# Altium schematic sheet 主拓扑.SchDoc(主拓扑)
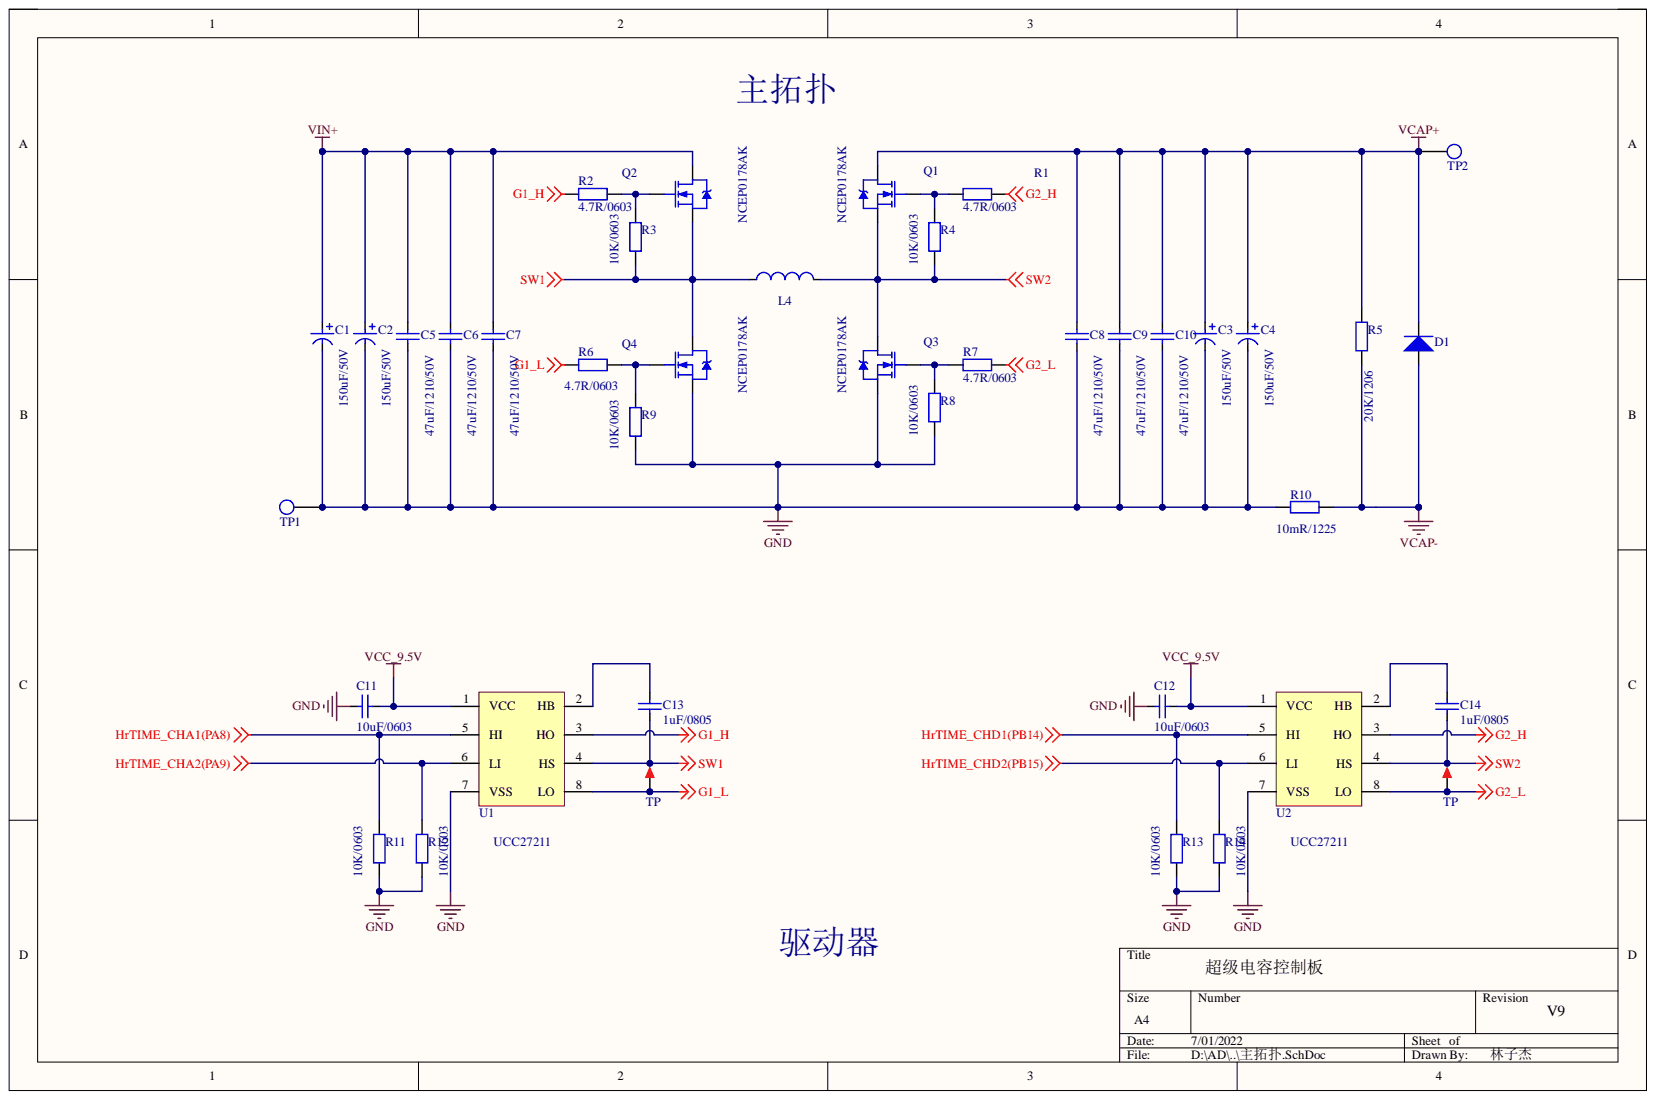
[diagram p0: 主拓扑.SchDoc]

## Parts
ADC_ILOW?  TP  [TEST_1.4TP]
ADC_ILOW?  TP  [TEST_1.4TP]
C1  150uF/50V  [CAP_CM(10*10.2)]
C2  150uF/50V  [CAP_CM(10*10.2)]
C3  150uF/50V  [CAP_CM(10*10.2)]
C4  150uF/50V  [CAP_CM(10*10.2)]
C5  47uF  value 47uF/1210/50V  [CAP_1210]
C6  47uF  value 47uF/1210/50V  [CAP_1210]
C7  47uF  value 47uF/1210/50V  [CAP_1210]
C8  47uF  value 47uF/1210/50V  [CAP_1210]
C9  47uF  value 47uF/1210/50V  [CAP_1210]
C10  47uF  value 47uF/1210/50V  [CAP_1210]
C11  10uF  value 10uF/0603  [CAP_0603]
C12  10uF  value 10uF/0603  [CAP_0603]
C13  1uF  value 1uF/0805  [CAP_0805]
C14  1uF  value 1uF/0805  [CAP_0805]
D1  SMAJ75A  [DO-214AC]  (value not drawn)
L4  47uH  [IND_20315]  (value not drawn)
Q1  NCEP0178AK  [TO-252]
Q2  NCEP0178AK  [TO-252]
Q3  NCEP0178AK  [TO-252]
Q4  NCEP0178AK  [TO-252]
R1  4.7R  value 4.7R/0603  [RES_0603]
R2  4.7R  value 4.7R/0603  [RES_0603]
R3  10K  value 10K/0603  [RES_0603]
R4  value 10K/0603  [RES_0603]  (hidden comment: 10K)
R5  20K  value 20K/1206  [RES_1206]
R6  4.7R  value 4.7R/0603  [RES_0603]
R7  4.7R  value 4.7R/0603  [RES_0603]
R8  value 10K/0603  [RES_0603]  (hidden comment: 10K)
R9  10K  value 10K/0603  [RES_0603]
R10  10mR  value 10mR/1225  [RES_1225]
R11  10K  value 10K/0603  [RES_0603]
R12  10K  value 10K/0603  [RES_0603]
R13  10K  value 10K/0603  [RES_0603]
R14  10K  value 10K/0603  [RES_0603]
TP1  ??  [TP]  (value not drawn)
TP2  ??  [TP]  (value not drawn)
U1  UCC27211  [SOP-8 - BIG]
U2  UCC27211  [SOP-8 - BIG]

## Nets
G1_H: R2.1 U1.3(HO)
G1_L: ADC_ILOW?.1 R6.1 U1.8(LO)
G2_H: R1.1 U2.3(HO)
G2_L: ADC_ILOW?.1 R7.1 U2.8(LO)
GND: C1.2 C2.2 C3.2 C4.2 C5.1 C6.1 C7.1 C8.1 C9.1 C10.1 C11.1 C12.1 Q3.3 Q4.3 R8.1 R9.1 R10.1 R11.1 R12.1 R13.1 R14.1 TP1.1 U1.7(VSS) U2.7(VSS)
HrTIME_CHA1(PA8): R11.2 U1.5(HI)
HrTIME_CHA2(PA9): R12.2 U1.6(LI)
HrTIME_CHD1(PB14): R13.2 U2.5(HI)
HrTIME_CHD2(PB15): R14.2 U2.6(LI)
SW1: C13.1 L4.1 Q2.3 Q4.2 R3.1 U1.4(HS)
SW2: C14.1 L4.2 Q1.3 Q3.2 R4.1 U2.4(HS)
VCAP+: C3.1 C4.1 C8.2 C9.2 C10.2 D1.2 Q1.2 R5.2 TP2.1
VCAP-: D1.1 R5.1 R10.2
VCC_9.5V: C11.2 C12.2 U1.1(VCC) U2.1(VCC)
VIN+: C1.1 C2.1 C5.2 C6.2 C7.2 Q2.2
NetC13_2: C13.2 U1.2(HB)
NetC14_2: C14.2 U2.2(HB)
NetQ1_1: Q1.1 R1.2 R4.2
NetQ2_1: Q2.1 R2.2 R3.2
NetQ3_1: Q3.1 R7.2 R8.2
NetQ4_1: Q4.1 R6.2 R9.2

## Library data of the parts
ADC_ILOW? = TEST TP: 极性电容; BOM名称: 测试环; 联系卖家，免费查找封装: 唯一出售店铺网址https://shop463601444.taobao.com; Component Kind: Standard; Library Name: 0.7 - 常用按键-开关-蜂鸣器-电池-测试点.SchLib
ADC_ILOW? = TEST TP: 测试环; 联系卖家，免费查找封装: 唯一出售店铺网址https://shop463601444.taobao.com; BOM名称: 测试环; Component Kind: Standard; Library Name: 0.7 - 常用按键-开关-蜂鸣器-电池-测试点.SchLib
C1-C4 = Cap_ELEC: 极性电容; Component Kind: Standard; Library Name: LIN_Library .SchLib
C5-C10 = Cap_1210: Capacitor; Component Kind: Standard; Library Name: LIN_Library .SchLib
C11 C12 = Cap_0603: Capacitor; Component Kind: Standard; Library Name: LIN_Library .SchLib
C13 C14 = Cap_0805: Capacitor; Component Kind: Standard; Library Name: LIN_Library .SchLib
D1 = Diode_SMA: Diode; Component Kind: Standard; Library Name: LIN_Library .SchLib
L4 = Ind: 磁环电感; Component Kind: Standard; Library Name: LIN_Library .SchLib
Q1-Q4 = MOSFET_N: N-MOSFET; Note: Pin 2 is electrically connected to pin 4.; Component Kind: Standard; ComponentLink1Description: Manufacturer Link; ComponentLink1URL: http://www.irf.com/; DatasheetVersion: PD-9.814; LatestRevisionDate: 17-Jul-2002; LatestRevisionNote: Re-released for DXP Platform.; PackageDescription: TO, Thru-Hole, Vertical, Heatsink Mounted; 3 In-Line Leads; Pitch 2.54 mm; PackageReference: TO-220AB; PackageVersion: Feb-1997; Published: 8-Jun-2000; Library Name: LIN_Library .SchLib; Publisher: Altium Limited
R1-R3 R6 R7 R9-R14 = Res_0603: Resistor; Component Kind: Standard; Library Name: LIN_Library .SchLib
R4 R8 = Res_0603: Resistor; Value: 10K/0603; Component Kind: Standard; Library Name: LIN_Library .SchLib
R5 = Res_1206: Resistor; Component Kind: Standard; Library Name: LIN_Library .SchLib
TP1 TP2 = TP: Component Kind: Standard; Library Name: LIN_Library .SchLib
U1 U2 = UCC27211: Gate drive,MOSFET、IGBT drive; Component Kind: Standard; Library Name: LIN_Library .SchLib
